# Altium schematic sheet [06] - POWER.SchDoc(POWER)
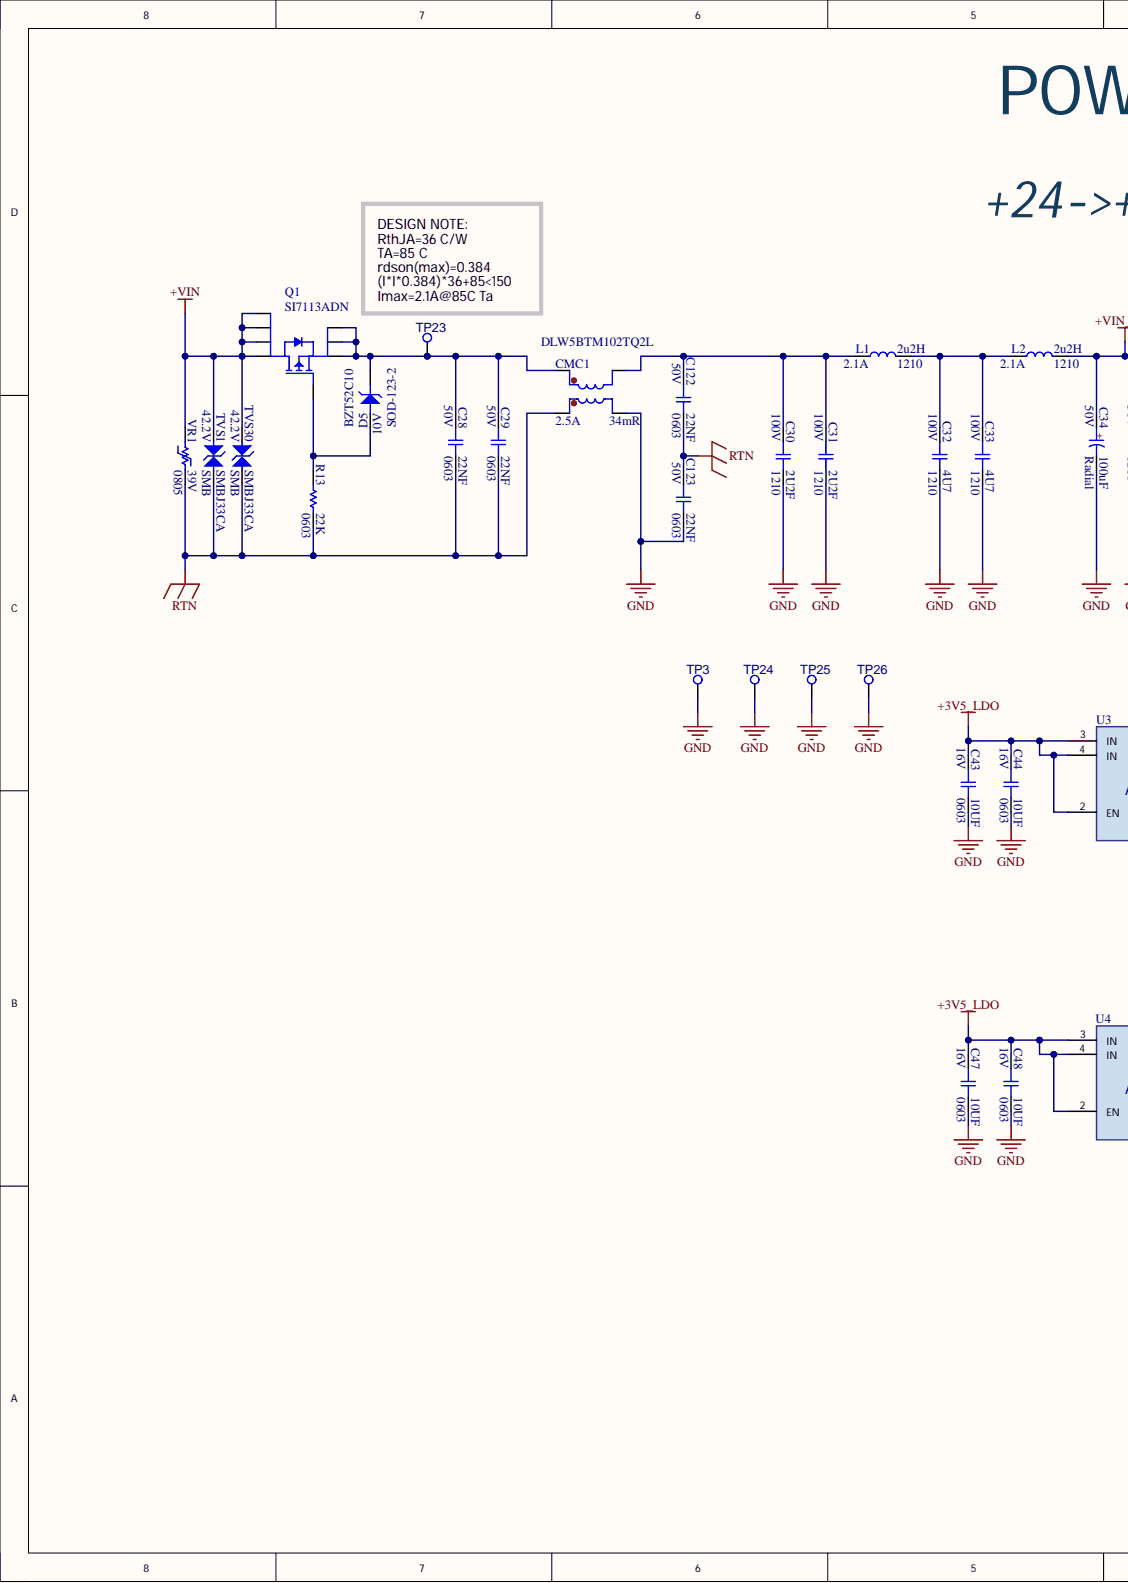
[diagram p0: [06] - POWER.SchDoc, left]
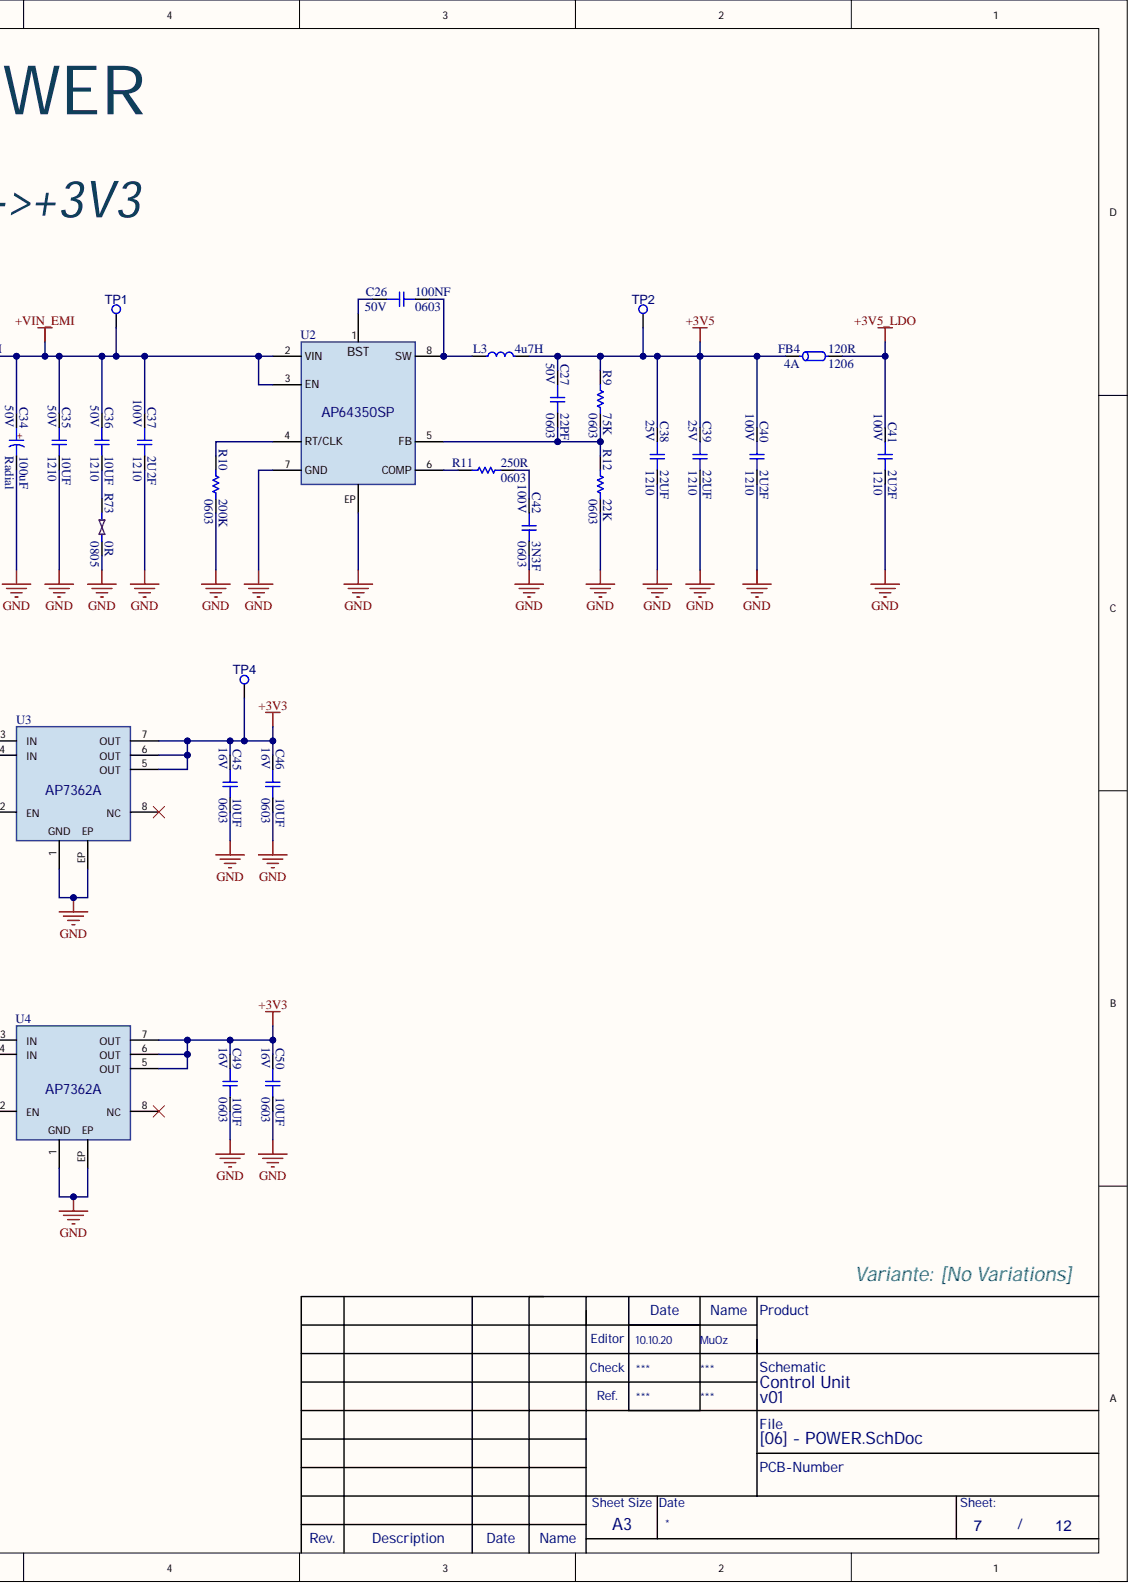
[diagram p1: [06] - POWER.SchDoc, right]

## Parts
C26  100NF  Case Code (Imperial): 0603  Voltage: 50V  [C-0603]
C27  22PF  Case Code (Imperial): 0603  Voltage: 50V  [C-0603]
C28  22NF  Case Code (Imperial): 0603  Voltage: 50V  [C-0603]
C29  22NF  Case Code (Imperial): 0603  Voltage: 50V  [C-0603]
C30  2U2F  Case Code (Imperial): 1210  Voltage: 100V  [C-1210]
C31  2U2F  Case Code (Imperial): 1210  Voltage: 100V  [C-1210]
C32  4U7  Case/Package: 1210  Voltage Rating: 100V  [C-1210]
C33  4U7  Case/Package: 1210  Voltage Rating: 100V  [C-1210]
C34  Case/Package: Radial  Voltage: 50V  [C-E-8.3x8.3x10.2]  (hidden comment: 100UF)
C35  10UF  Case Code (Imperial): 1210  Voltage: 50V  [C-1210]
C36  10UF  Case Code (Imperial): 1210  Voltage: 50V  [C-1210]
C37  2U2F  Case Code (Imperial): 1210  Voltage: 100V  [C-1210]
C38  22UF  Case Code (Imperial): 1210  Voltage: 25V  [C-1210]
C39  22UF  Case Code (Imperial): 1210  Voltage: 25V  [C-1210]
C40  2U2F  Case Code (Imperial): 1210  Voltage: 100V  [C-1210]
C41  2U2F  Case Code (Imperial): 1210  Voltage: 100V  [C-1210]
C42  3N3F  Case Code (Imperial): 0603  Voltage Rating: 100V  [C-0603]
C43  10UF  Case Code (Imperial): 0603  Voltage: 16V  [C-0603]
C44  10UF  Case Code (Imperial): 0603  Voltage: 16V  [C-0603]
C45  10UF  Case Code (Imperial): 0603  Voltage: 16V  [C-0603]
C46  10UF  Case Code (Imperial): 0603  Voltage: 16V  [C-0603]
C47  10UF  Case Code (Imperial): 0603  Voltage: 16V  [C-0603]
C48  10UF  Case Code (Imperial): 0603  Voltage: 16V  [C-0603]
C49  10UF  Case Code (Imperial): 0603  Voltage: 16V  [C-0603]
C50  10UF  Case Code (Imperial): 0603  Voltage: 16V  [C-0603]
C122  22NF  Case Code (Imperial): 0603  Voltage: 50V  [C-0603]
C123  22NF  Case Code (Imperial): 0603  Voltage: 50V  [C-0603]
CMC1  DLW5BTM102TQ2L  DC Resistance (DCR): 34mR  Max Current Rating: 2.5A  [CMC-DLW5BTM]
D5  BZT52C10  Case/Package: SOD-123-2  Voltage Rating (DC): 10V  [D-SOD123]
FB4  120R  Case Code (Imperial): 1206  Current Rating: 4A  [FB-1206]
L1  2u2H  Case Code (Imperial): 1210  Current Rating: 2.1A  [WE-PMCI_1210_H1]
L2  2u2H  Case Code (Imperial): 1210  Current Rating: 2.1A  [WE-PMCI_1210_H1]
L3  4u7H  [WE-MAPI_5030]
Q1  SI7113ADN  [PowerPAK 1212-8]
R9  75K  Case Code (Imperial): 0603  [R-0603]
R10  200K  Case Code (Imperial): 0603  [R-0603]
R11  250R  Case Code (Imperial): 0603  [R-0603]
R12  22K  Case Code (Imperial): 0603  [R-0603]
R13  22K  Case Code (Imperial): 0603  [R-0603]
R73  0R  Case Code (Imperial): 0805  [JMP-0805]
TP1  MECH-TESTPOINT  [PAD TH]  (value not drawn)  (no BOM)
TP2  MECH-TESTPOINT  [PAD TH]  (value not drawn)  (no BOM)
TP3  MECH-TESTPOINT  [PAD TH]  (value not drawn)  (no BOM)
TP4  MECH-TESTPOINT  [PAD TH]  (value not drawn)  (no BOM)
TP23  MECH-TESTPOINT  [MECH-TESTPOINT]  (value not drawn)  (no BOM)
TP24  MECH-TESTPOINT  [PAD TH]  (value not drawn)  (no BOM)
TP25  MECH-TESTPOINT  [PAD TH]  (value not drawn)  (no BOM)
TP26  MECH-TESTPOINT  [PAD TH]  (value not drawn)  (no BOM)
TVS1  SMBJ33CA  Breakdown Voltage: 42.2V  Case/Package: SMB  [D-SMB-DO214AA-CA]
TVS30  SMBJ33CA  Breakdown Voltage: 42.2V  Case/Package: SMB  [D-SMB-DO214AA-CA]
U2  AP64350SP  [SOIC8-EP]
U3  AP7362A  [SOIC8-EP]
U4  AP7362A  [SOIC8-EP]
VR1  Case Code (Imperial): 0805  Varistor Voltage: 39V  [R-0805]  (hidden comment: B72510E1250K62)

## Nets
+3V3: C45.1 C46.1 C49.1 C50.1 TP4.1 U3.5(OUT) U3.6(OUT) U3.7(OUT) U4.5(OUT) U4.6(OUT) U4.7(OUT)
+3V5: C27.1 C38.1 C39.1 C40.1 FB4.1 L3.2 R9.1 TP2.1
+3V5_LDO: C41.1 C43.1 C44.1 C47.1 C48.1 FB4.2 U3.2(EN) U3.3(IN) U3.4(IN) U4.2(EN) U4.3(IN) U4.4(IN)
+VIN: Q1.5 Q1.6 Q1.7 Q1.8 TVS1.A TVS30.A VR1.1
+VIN_EMI: C34.1 C35.1 C36.1 C37.1 L2.2 TP1.1 U2.2(VIN) U2.3(EN)
GND: C30.2 C31.2 C32.2 C33.2 C34.2 C35.2 C37.2 C38.2 C39.2 C40.2 C41.2 C42.2 C43.2 C44.2 C45.2 C46.2 C47.2 C48.2 C49.2 C50.2 C123.2 CMC1.2 R10.2 R12.2 R73.2 TP3.1 TP24.1 TP25.1 TP26.1 U2.7(GND) U2.EP U3.1 U3.EP U4.1 U4.EP
RTN: C28.2 C29.2 C122.2 C123.1 CMC1.1 R13.2 TVS1.C TVS30.C VR1.2
NetC26_1: C26.1 U2.1
NetC26_2: C26.2 L3.1 U2.8(SW)
NetC27_2: C27.2 R9.2 R12.1 U2.5(FB)
NetC28_1: C28.1 C29.1 CMC1.4 D5.C Q1.1 Q1.2 Q1.3 TP23.1
NetC30_1: C30.1 C31.1 C122.1 CMC1.3 L1.1
NetC32_1: C32.1 C33.1 L1.2 L2.1
NetC36_2: C36.2 R73.1
NetC42_1: C42.1 R11.2
NetD5_A: D5.A Q1.4 R13.1
NetR10_1: R10.1 U2.4(RT/CLK)
NetR11_1: R11.1 U2.6(COMP)

Not connected: U3 8(NC); U4 8(NC)

## Library data of the parts
C26 = C-100NF-0603: CAP CER 0.1UF 50V X7R 0603; Manufacturer: TDK; Manufacturer Part Number: C1608X7R1H104K080AA; .Creator: F:TALI; .PCBCHK: CHECKED; Dielectric Material: Ceramic; Temperature Coefficient: 30ppm/°C; Tolerance: 10%; Washability: OK; .SCHCHK: CHECKED; Capacitance: 100nF; Case Code (Metric): 1608; Case/Package: 0603; Component Kind: Standard; ComponentLink1Description: http://www.mouser.com/ds/2/400/lcc_commercial_general_en-837201.pdf; ComponentLink1URL: http://www.mouser.com/ds/2/400/lcc_commercial_general_en-837201.pdf; ComponentLink2Description: https://product.tdk.com/info/en/catalog/spec/mlccspec_commercial_general_midvoltage_en.pdf; ComponentLink2URL: https://product.tdk.com/info/en/catalog/spec/mlccspec_commercial_general_midvoltage_en.pdf; ComponentLink3Description: http://uk.rs-online.com/webdocs/13d1/0900766b813d1103.pdf; ComponentLink3URL: http://uk.rs-online.com/webdocs/13d1/0900766b813d1103.pdf; ComponentLink4Description: http://www.tdk.co.jp/tefe02/e412_c.pdf; ComponentLink4URL: http://www.tdk.co.jp/tefe02/e412_c.pdf; ComponentLink5Description: http://www.farnell.com/datasheets/2291921.pdf; ComponentLink5URL: http://www.farnell.com/datasheets/2291921.pdf; ComponentLink6Description: http://www.farnell.com/datasheets/1744655.pdf; ComponentLink6URL: http://www.farnell.com/datasheets/1744655.pdf; ComponentLink7Description: http://catalogs.avx.com/SurfaceMount.pdf; ComponentLink7URL: http://catalogs.avx.com/SurfaceMount.pdf; ComponentLink8Description: http://www.farnell.com/datasheets/630895.pdf; ComponentLink8URL: http://www.farnell.com/datasheets/630895.pdf; ComponentLink9Description: http://www.avx.com/docs/Catalogs/cx7r.pdf; ComponentLink9URL: http://www.avx.com/docs/Catalogs/cx7r.pdf; ComponentLink10Description: http://www.avx.com/docs/catalogs/cauto.pdf; ComponentLink10URL: http://www.avx.com/docs/catalogs/cauto.pdf; ComponentLink11Description: https://www.verical.com/server-webapp/html/getDatasheet?partID=98691; ComponentLink11URL: https://www.verical.com/server-webapp/html/getDatasheet?partID=98691; ComponentLink12Description: https://www.avx.com/docs/Catalogs/flexiterm.pdf; ComponentLink12URL: https://www.avx.com/docs/Catalogs/flexiterm.pdf; Composition: Ceramic; Contact Plating: Matte,Tin; Depth: 0.8mm; Dielectric: X7R; Features: Soft Termination; Height: 0.8mm; Lead Free: Lead Free; Length: 1.6mm; Max Operating Temperature: 125°C; Min Operating Temperature: -55°C; Mount: Surface Mount; Number of Pins: 2; Package Quantity: 1; Packaging: Tape and Reel; Pricing 1: 1=0.1, 10=0.057, 100=0.0254, 500=0.01812, 1000=0.01423 (USD); Pricing 2: 1=0.23, 10=0.161, 100=0.0756, 500=0.05304, 1000=0.0439 (USD); Radiation Hardening: No; REACH SVHC: No SVHC; Stock 1: 0; Stock 2: 40000; Supplier 1: Digi-Key; Supplier 2: Digi-Key; Supplier Part Number 1: 445-1314-1-ND; Supplier Part Number 2: 478-3540-6-ND; Termination: SMD/SMT; Thickness: 0.037inch; Voltage Rating: 50V; Voltage Rating (DC): 50V; Weight: 7.1E-05oz; Width: 0.8mm; Library Name: BARKO_SCHLIB.SchLib
C27 = C-22PF-0603: CAP CER 22PF 50V C0G/NP0 0603; Manufacturer: Samsung; Manufacturer Part Number: CL10C220JB8NNNC; .Creator: F:TALI; .PCBCHK: USED; Dielectric: C0G; Dielectric Material: Ceramic; Insulation Resistance: 100GR; Temperature Coefficient: 300ppm/°C; Tolerance: 5%; Washability: OK; .SCHCHK: USED; Capacitance: 22pF; Case Code (Metric): 1608; Case/Package: 0603; Component Kind: Standard; ComponentLink1Description: http://www1.futureelectronics.com/doc/SAMSUNG%20PASSIVE/CL05B104KQ5NNNC.pdf; ComponentLink1URL: http://www1.futureelectronics.com/doc/SAMSUNG%20PASSIVE/CL05B104KQ5NNNC.pdf; ComponentLink2Description: https://media.digikey.com/pdf/Data%20Sheets/Samsung%20PDFs/CL_Series_MLCC_ds.pdf; ComponentLink2URL: https://media.digikey.com/pdf/Data%20Sheets/Samsung%20PDFs/CL_Series_MLCC_ds.pdf; ComponentLink3Description: https://www.distrelec.biz/Web/Downloads/_e/ng/MLCC_CatalogDownload2013_ENG.pdf?mime=application%2Fpdf; ComponentLink3URL: https://www.distrelec.biz/Web/Downloads/_e/ng/MLCC_CatalogDownload2013_ENG.pdf?mime=application%2Fpdf; ComponentLink4Description: https://produktinfo.conrad.com/datenblaetter/450000-474999/457135-da-01-en-VS_KONDENSATOR_0603.pdf; ComponentLink4URL: https://produktinfo.conrad.com/datenblaetter/450000-474999/457135-da-01-en-VS_KONDENSATOR_0603.pdf; ComponentLink5Description: http://www.avx.com/docs/Catalogs/ccog.pdf; ComponentLink5URL: http://www.avx.com/docs/Catalogs/ccog.pdf; ComponentLink6Description: https://www.verical.com/server-webapp/html/getDatasheet?partID=60906; ComponentLink6URL: https://www.verical.com/server-webapp/html/getDatasheet?partID=60906; ComponentLink7Description: http://www.farnell.com/datasheets/759117.pdf; ComponentLink7URL: http://www.farnell.com/datasheets/759117.pdf; ComponentLink8Description: http://catalogs.avx.com/SurfaceMount.pdf; ComponentLink8URL: http://catalogs.avx.com/SurfaceMount.pdf; ComponentLink9Description: http://uk.rs-online.com/webdocs/140c/0900766b8140c8fe.pdf; ComponentLink9URL: http://uk.rs-online.com/webdocs/140c/0900766b8140c8fe.pdf; ComponentLink10Description: http://www1.futureelectronics.com/doc/SAMSUNG/CL10C220JB8NFNC.pdf; ComponentLink10URL: http://www1.futureelectronics.com/doc/SAMSUNG/CL10C220JB8NFNC.pdf; ComponentLink11Description: http://www.samsungsem.com/kr/support/product-search/mlcc/CL10C220JB8NFNC.jsp; ComponentLink11URL: http://www.samsungsem.com/kr/support/product-search/mlcc/CL10C220JB8NFNC.jsp; Contact Plating: Tin; Depth: 0.8mm; Height: 0.8mm; Lead Free: Lead Free; Length: 1.6mm; Material: Ceramic; Max Operating Temperature: 125°C; Min Operating Temperature: -55°C; Mount: Surface Mount; Number of Pins: 2; Package Quantity: 1; Packaging: Tape and Reel; Pricing 1: 1=0.1, 10=0.056, 100=0.0251, 500=0.0179, 1000=0.01406 (USD); Pricing 2: 1=0.1, 10=0.073, 100=0.0324, 500=0.02314, 1000=0.01818 (USD); Pricing 3: 1=0.1, 10=0.048, 100=0.0214, 500=0.01532, 1000=0.01203 (USD); Radiation Hardening: No; REACH SVHC: No SVHC; Stock 1: 0; Stock 2: 40000; Stock 3: 3383; Supplier 1: Digi-Key; Supplier 2: Digi-Key; Supplier 3: Digi-Key; Supplier Part Number 1: 1276-1023-6-ND; Supplier Part Number 2: 478-1167-6-ND; Supplier Part Number 3: 1276-2228-6-ND; Termination: SMD/SMT; Thickness: 0.035inch; Voltage Rating: 50V; Voltage Rating (DC): 50V; Width: 0.031inch; Library Name: BARKO_SCHLIB.SchLib
C28 C29 C122 C123 = C-22NF-0603: CAP CER 0.022UF 50V X7R 0603L; Manufacturer: Yageo; Manufacturer Part Number: CC0603KRX7R9BB223; .Creator: M.OZKAN; .PCBCHK: USED; Dielectric Material: Ceramic; Insulation Resistance: 100GR; Tolerance: 10%; Washability: OK; .SCHCHK: USED; Capacitance: 22nF; Case Code (Metric): 1608; Case/Package: 0603; Component Kind: Standard; ComponentLink1Description: https://datasheet.ciiva.com/156/mlccorderingcode-156177.pdf?src-supplier=Digi-Key; ComponentLink1URL: https://datasheet.ciiva.com/156/mlccorderingcode-156177.pdf?src-supplier=Digi-Key; ComponentLink2Description: https://datasheet.ciiva.com/156/mlccorderingcode-156178.pdf?src-supplier=Digi-Key; ComponentLink2URL: https://datasheet.ciiva.com/156/mlccorderingcode-156178.pdf?src-supplier=Digi-Key; ComponentLink3Description: https://datasheet.ciiva.com/156/mlccorderingcode-156179.pdf?src-supplier=Digi-Key; ComponentLink3URL: https://datasheet.ciiva.com/156/mlccorderingcode-156179.pdf?src-supplier=Digi-Key; ComponentLink4Description: https://datasheet.ciiva.com/11464/upy-gphc-x7r-6-3v-to-50v-8-11464741.pdf?src-supplier=Avnet; ComponentLink4URL: https://datasheet.ciiva.com/11464/upy-gphc-x7r-6-3v-to-50v-8-11464741.pdf?src-supplier=Avnet; ComponentLink5Description: https://datasheet.ciiva.com/8033/1489800-8033865.pdf?src-supplier=Element14; ComponentLink5URL: https://datasheet.ciiva.com/8033/1489800-8033865.pdf?src-supplier=Element14; ComponentLink6Description: https://datasheet.ciiva.com/8033/1489800-8033867.pdf?src-supplier=Element14; ComponentLink6URL: https://datasheet.ciiva.com/8033/1489800-8033867.pdf?src-supplier=Element14; ComponentLink7Description: https://datasheet.ciiva.com/16625/upy-gphc-x7r-6-3v-to-50v-8-16625532.pdf?src-supplier=Avnet+Europe; ComponentLink7URL: https://datasheet.ciiva.com/16625/upy-gphc-x7r-6-3v-to-50v-8-16625532.pdf?src-supplier=Avnet+Europe; ComponentLink8Description: https://datasheet.ciiva.com/8033/70765-8033869.pdf?src-supplier=Element14; ComponentLink8URL: https://datasheet.ciiva.com/8033/70765-8033869.pdf?src-supplier=Element14; ComponentLink9Description: https://datasheet.ciiva.com/28450/1489800-28450639.pdf?src-supplier=Element14; ComponentLink9URL: https://datasheet.ciiva.com/28450/1489800-28450639.pdf?src-supplier=Element14; Composition: Ceramic; Depth: 0.8mm; Dielectric: X7R; Halogen Free: Halogen Free; Height: 0.8mm; Lead Free: Lead Free; Length: 1.6mm; Max Operating Temperature: 125°C; Min Operating Temperature: -55°C; Mount: Surface Mount; Number of Pins: 2; Package Quantity: 1; Packaging: Tape and Reel; Pricing 1: 1=0.10000, 10=0.06700, 100=0.03000, 500=0.02140, 1000=0.01682 (USD); Radiation Hardening: No; REACH SVHC: No SVHC; RoHS: Yes; Stock 1: 144094; Supplier 1: Digi-Key; Supplier Part Number 1: 311-1370-1-ND; Termination: SMD/SMT; Thickness: 0.035inch; Voltage Rating: 50V; Voltage Rating (DC): 50V; Width: 0.031inch; Library Name: BARKO_SCHLIB.SchLib
C30 C31 C37 C40 C41 = C-2U2F-1210: CAP CER 2.2UF 100V X7R 1210; Manufacturer: Samsung; Manufacturer Part Number: CL32B225KCJSNNE; .Creator: F:TALI; .PCBCHK: CHECKED; Tolerance: 10%; Washability: OK; .SCHCHK: CHECKED; Capacitance: 2.2uF; Case Code (Metric): 3225; Case/Package: 1210; Component Kind: Standard; ComponentLink1Description: http://www1.futureelectronics.com/doc/SAMSUNG/CL32B225KCJSNNE.pdf; ComponentLink1URL: http://www1.futureelectronics.com/doc/SAMSUNG/CL32B225KCJSNNE.pdf; ComponentLink2Description: http://www.samsungsem.com/kr/support/product-search/mlcc/CL32B225KCJSNNE .jsp; ComponentLink2URL: http://www.samsungsem.com/kr/support/product-search/mlcc/CL32B225KCJSNNE .jsp; ComponentLink3Description: https://www.verical.com/server-webapp/html/getDatasheet?partID=357497; ComponentLink3URL: https://www.verical.com/server-webapp/html/getDatasheet?partID=357497; ComponentLink4Description: http://www.mouser.com/ds/2/400/lcc_commercial_general_en-837201.pdf; ComponentLink4URL: http://www.mouser.com/ds/2/400/lcc_commercial_general_en-837201.pdf; ComponentLink5Description: http://www.farnell.com/datasheets/1698875.pdf; ComponentLink5URL: http://www.farnell.com/datasheets/1698875.pdf; ComponentLink6Description: http://www.farnell.com/datasheets/1484596.pdf; ComponentLink6URL: http://www.farnell.com/datasheets/1484596.pdf; ComponentLink7Description: https://www.verical.com/server-webapp/html/getDatasheet?partID=725361; ComponentLink7URL: https://www.verical.com/server-webapp/html/getDatasheet?partID=725361; ComponentLink8Description: http://product.tdk.com/en/catalog/datasheets/mlcc_commercial_midvoltage_en.pdf; ComponentLink8URL: http://product.tdk.com/en/catalog/datasheets/mlcc_commercial_midvoltage_en.pdf; Composition: Ceramic; Contact Plating: Tin; Depth: 2.5mm; Dielectric: X7R; Features: Soft Termination; Height: 2.5mm; Lead Free: Lead Free; Length: 3.2mm; Max Operating Temperature: 125°C; Min Operating Temperature: -55°C; Mount: Surface Mount; Number of Pins: 2; Package Quantity: 1; Packaging: Tape and Reel; Pricing 1: 1=0.58, 10=0.41, 100=0.27, 500=0.2 (USD); Pricing 2: 1=0.92, 10=0.648, 100=0.4269, 500=0.31626 (USD); Pricing 3: 1=1.42, 10=1.121, 100=0.8409 (USD); Radiation Hardening: No; Ratings: AEC-Q200; REACH SVHC: No SVHC; Stock 1: 0; Stock 2: 0; Stock 3: 0; Supplier 1: Digi-Key; Supplier 2: Digi-Key; Supplier 3: Digi-Key; Supplier Part Number 1: 1276-3362-6-ND; Supplier Part Number 2: 445-4497-6-ND; Supplier Part Number 3: 587-4235-6-ND; Termination: SMD/SMT; Thickness: 0.106inch; Voltage Rating: 100V; Voltage Rating (DC): 100V; Weight: 0.000564oz; Width: 0.098inch; Library Name: BARKO_SCHLIB.SchLib
C32 C33 = C-4U7-1210-100V: CAP CER 4.7UF 100V X7S 1210; Manufacturer: Taiyo Yuden; Manufacturer Part Number: HMK325C7475KM-PE; Tolerance: 10%; Washability: OK; Capacitance: 4.7uF; Component Kind: Standard; ComponentLink1Description: http://ds.yuden.co.jp/TYCOMPAS/ut/detail.do?productNo=HMK325C7475KM-PE&amp;fileName=HMK325C7475KM-PE_SS&amp;mode=specSheetDownload&src-supplier=Digi-Key; ComponentLink1URL: http://ds.yuden.co.jp/TYCOMPAS/ut/detail.do?productNo=HMK325C7475KM-PE&amp;fileName=HMK325C7475KM-PE_SS&amp;mode=specSheetDownload&src-supplier=Digi-Key; Max Operating Temperature: 125°C; Min Operating Temperature: -55°C; Mount: Surface Mount; Packaging: Tape and Reel; Pricing 1: 1=1.10000, 10=0.83900, 100=0.60030, 500=0.46474 (USD); RoHS: No; Stock 1: 0; Supplier 1: Digi-Key; Supplier Part Number 1: 587-HMK325C7475KM-PECT-ND; Library Name: BARKO_SCHLIB.SchLib
C34 = C-100UF-SMD: CAP ALUM 100UF 20% 50V SMD; Manufacturer: Nichicon; Manufacturer Part Number: UUD1H101MNL1GS; .Creator: M.OZKAN; .PCBCHK: CHECKED; Dielectric Material: Aluminium; Dissipation Factor: 0.14%; Impedance: 340mR; Lead Diameter: 1.1mm; Leakage Current: 3uA; Life (Hours): 5000Hour; Ripple Current: 300mA; Washability: OK; .SCHCHK: CHECKED; Capacitance: 100uF; Component Kind: Standard; ComponentLink1Description: http://www.farnell.com/datasheets/2100017.pdf; ComponentLink1URL: http://www.farnell.com/datasheets/2100017.pdf; ComponentLink2Description: http://nichicon-us.com/english/products/pdfs/e-uud.pdf; ComponentLink2URL: http://nichicon-us.com/english/products/pdfs/e-uud.pdf; ComponentLink3Description: http://www.nichicon.co.jp/english/products/pdfs/e-ch_ref.pdf; ComponentLink3URL: http://www.nichicon.co.jp/english/products/pdfs/e-ch_ref.pdf; ComponentLink4Description: http://nichicon-us.com/english/products/pdfs/e-ud.pdf; ComponentLink4URL: http://nichicon-us.com/english/products/pdfs/e-ud.pdf; ComponentLink5Description: http://www.farnell.com/datasheets/1910936.pdf; ComponentLink5URL: http://www.farnell.com/datasheets/1910936.pdf; ComponentLink6Description: http://www.farnell.com/datasheets/66055.pdf; ComponentLink6URL: http://www.farnell.com/datasheets/66055.pdf; ComponentLink7Description: http://uk.rs-online.com/webdocs/0fa3/0900766b80fa39e7.pdf; ComponentLink7URL: http://uk.rs-online.com/webdocs/0fa3/0900766b80fa39e7.pdf; ComponentLink8Description: http://www.nichicon.co.jp/english/products/pdfs/e-ud.pdf; ComponentLink8URL: http://www.nichicon.co.jp/english/products/pdfs/e-ud.pdf; Diameter: 8mm; Height: 10mm; Height - Seated (Max): 0.394inch; Lead Free: Lead Free; Lead Pitch: 3.1mm; Length: 10mm; Max Operating Temperature: 105°C; Min Operating Temperature: -55°C; Number of Pins: 2; Package Quantity: 500; Packaging: Tape and Reel; Pricing 1: 1=0.75, 10=0.554, 100=0.3796 (USD); Radiation Hardening: No; REACH SVHC: No SVHC; Ripple Current (AC): 300mA; Stock 1: 72155; Supplier 1: Digi-Key; Supplier 2: Digi-Key; Supplier Part Number 1: 493-2306-6-ND; Supplier Part Number 2: 565-2495-6-ND; Termination: SMD/SMT; Tolerance: 20%; Voltage Rating: 50V; Voltage Rating (DC): 50V; Library Name: BARKO_SCHLIB.SchLib
C35 C36 = C-10UF-1210: CAP CER 10UF 50V X7R 1210; Manufacturer: Samsung; Manufacturer Part Number: CL32B106KBJNNNE; .Creator: M.OZKAN; .PCBCHK: CHECKED; Material: Ceramic; Tolerance: 10%; Washability: OK; .SCHCHK: CHECKED; Capacitance: 10uF; Case Code (Metric): 3225; Case/Package: 1210; Component Kind: Standard; ComponentLink1Description: http://www.samsungsem.com/kr/support/product-search/mlcc/CL32B106KBJNNNE .jsp; ComponentLink1URL: http://www.samsungsem.com/kr/support/product-search/mlcc/CL32B106KBJNNNE .jsp; ComponentLink2Description: https://produktinfo.conrad.com/datenblaetter/450000-474999/450821-da-01-en-VS_KONDENSATOR_1210.pdf; ComponentLink2URL: https://produktinfo.conrad.com/datenblaetter/450000-474999/450821-da-01-en-VS_KONDENSATOR_1210.pdf; ComponentLink3Description: https://www.yuden.co.jp/productdata/catalog/mlcc_all_e.pdf; ComponentLink3URL: https://www.yuden.co.jp/productdata/catalog/mlcc_all_e.pdf; ComponentLink4Description: http://www.samsungsem.com/kr/support/product-search/mlcc/CL32B106KBJNNWE.jsp; ComponentLink4URL: http://www.samsungsem.com/kr/support/product-search/mlcc/CL32B106KBJNNWE.jsp; Depth: 2.5mm; Dielectric: X7R; Halogen Free: Halogen Free; Height: 2.5mm; Lead Free: Lead Free; Length: 3.2mm; Max Operating Temperature: 125°C; Min Operating Temperature: -55°C; Mount: Surface Mount; Package Quantity: 1; Packaging: Tape and Reel; Pricing 1: 1=0.96, 10=0.704, 100=0.483, 500=0.38238 (USD); Pricing 2: 1=1.02, 10=0.755, 100=0.5175 (USD); Pricing 3: 1=0.86, 10=0.61, 100=0.4017, 500=0.29756 (USD); Radiation Hardening: No; Stock 1: 0; Stock 2: 0; Stock 3: 0; Supplier 1: Digi-Key; Supplier 2: Digi-Key; Supplier 3: Digi-Key; Supplier Part Number 1: 1276-3387-6-ND; Supplier Part Number 2: 587-3167-6-ND; Supplier Part Number 3: 1276-3388-6-ND; Termination: SMD/SMT; Thickness: 0.106inch; Voltage Rating: 50V; Voltage Rating (DC): 50V; Width: 0.098inch; Library Name: BARKO_SCHLIB.SchLib
C38 C39 = C-22UF-1210: CAP CER 22UF 25V X7R 1210; Manufacturer: Samsung; Manufacturer Part Number: CL32B226KAJNNNE; .Creator: F:TALI; .PCBCHK: CHECKED; Dielectric Material: Ceramic; Dissipation Factor: 10%; Washability: OK; .SCHCHK: CHECKED; Capacitance: 22uF; Case Code (Metric): 3225; Case/Package: 1210; Component Kind: Standard; ComponentLink1Description: https://media.digikey.com/pdf/Data%20Sheets/Samsung%20PDFs/CL_Series_MLCC_ds.pdf; ComponentLink1URL: https://media.digikey.com/pdf/Data%20Sheets/Samsung%20PDFs/CL_Series_MLCC_ds.pdf; ComponentLink2Description: http://www.samsungsem.com/kr/support/product-search/mlcc/CL32B226KAJNFNE.jsp; ComponentLink2URL: http://www.samsungsem.com/kr/support/product-search/mlcc/CL32B226KAJNFNE.jsp; Depth: 2.5mm; Dielectric: X7R; Height: 2.5mm; Lead Free: Lead Free; Length: 3.2mm; Material: Ceramic; Max Operating Temperature: 125°C; Min Operating Temperature: -55°C; Mount: Surface Mount; Package Quantity: 1; Packaging: Tape and Reel; Pricing 1: 1=1.02, 10=0.777, 100=0.556, 500=0.43042 (USD); Pricing 2: 1=0.91, 10=0.671, 100=0.4598, 500=0.36402 (USD); Pricing 3: 1=1.63, 10=1.289, 100=0.9664, 500=0.7302 (USD); Radiation Hardening: No; Stock 1: 0; Stock 2: 0; Stock 3: 0; Supplier 1: Digi-Key; Supplier 2: Digi-Key; Supplier 3: Digi-Key; Supplier Part Number 1: 1276-3392-1-ND; Supplier Part Number 2: 1276-3391-6-ND; Supplier Part Number 3: 399-11958-6-ND; Termination: SMD/SMT; Thickness: 0.106inch; Tolerance: 10%; Voltage Rating: 25V; Voltage Rating (DC): 25V; Width: 0.098inch; Library Name: BARKO_SCHLIB.SchLib
C42 = C-3N3F-0603: CAP CER 3300PF 100V X7R 0603; Manufacturer: TDK; Manufacturer Part Number: CGA3E2X7R2A332K080AA; .Creator: F:TALI; .PCBCHK: USED; Capacitance: 3.3nF; Tolerance: 10%; Washability: OK; .SCHCHK: USED; Case Code (Metric): 1608; Case/Package: 0603; Component Kind: Standard; ComponentLink1Description: http://www.mouser.com/ds/2/400/lcc_automotive_midvoltage_en-355485.pdf; ComponentLink1URL: http://www.mouser.com/ds/2/400/lcc_automotive_midvoltage_en-355485.pdf; ComponentLink2Description: http://www.farnell.com/datasheets/1807242.pdf; ComponentLink2URL: http://www.farnell.com/datasheets/1807242.pdf; ComponentLink3Description: http://search.murata.co.jp/Ceramy/image/img/w_hinm/S0717E.pdf; ComponentLink3URL: http://search.murata.co.jp/Ceramy/image/img/w_hinm/S0717E.pdf; ComponentLink4Description: http://psearch.murata.com/capacitor/product/GCM188R72A332KA37%23.pdf; ComponentLink4URL: http://psearch.murata.com/capacitor/product/GCM188R72A332KA37%23.pdf; Composition: Ceramic; Depth: 0.8mm; Dielectric: X7R; Height: 0.8mm; Lead Free: Lead Free; Length: 1.6mm; Max Operating Temperature: 125°C; Min Operating Temperature: -55°C; Mount: Surface Mount; Package Quantity: 4000; Packaging: Tape and Reel; Pricing 1: 1=0.1, 10=0.076, 100=0.0338, 500=0.02416, 1000=0.01898 (USD); Pricing 2: 1=0.16, 10=0.114, 100=0.0535, 500=0.03748, 1000=0.03103 (USD); Radiation Hardening: No; Ratings: AEC-Q200; REACH SVHC: No SVHC; Stock 1: 22990; Stock 2: 40000; Supplier 1: Digi-Key; Supplier 2: Digi-Key; Supplier Part Number 1: 445-5809-6-ND; Supplier Part Number 2: 490-14767-6-ND; Termination: SMD/SMT; Thickness: 0.035inch; Voltage Rating (DC): 100V; Weight: 7.1E-05oz; Width: 0.031inch; Library Name: BARKO_SCHLIB.SchLib
C43-C50 = C-10UF-0603: CAP CER 10UF 16V X6S 0603; Manufacturer: Murata; Manufacturer Part Number: GRM188C81C106MA73D; .Creator: M.OZKAN; .PCBCHK: USED; Material: Ceramic; Tolerance: 20%; Washability: OK; .SCHCHK: USED; Capacitance: 10uF; Case Code (Metric): 1608; Case/Package: 0603; Component Kind: Standard; ComponentLink1Description: https://www.murata.com/~/media/webrenewal/support/library/catalog/products/capacitor/mlcc/c02e.ashx?la=en-us; ComponentLink1URL: https://www.murata.com/~/media/webrenewal/support/library/catalog/products/capacitor/mlcc/c02e.ashx?la=en-us; Composition: Ceramic; Depth: 0.8mm; Dielectric: X6S; Height: 0.8mm; Lead Free: Lead Free; Length: 1.6mm; Max Operating Temperature: 105°C; Min Operating Temperature: -55°C; Mount: Surface Mount; Package Quantity: 4000; Packaging: Tape and Reel; Pricing 1: 1=0.53, 10=0.366, 100=0.2195, 500=0.16464, 1000=0.13903 (USD); Pricing 2: 1=0.3, 10=0.205, 100=0.1159, 500=0.08184, 1000=0.07161 (USD); REACH SVHC: No SVHC; Stock 1: 0; Stock 2: 1927; Supplier 1: Digi-Key; Supplier 2: Digi-Key; Supplier Part Number 1: 490-7198-6-ND; Supplier Part Number 2: 1276-6769-6-ND; Termination: SMD/SMT; Thickness: 0.031inch; Voltage Rating: 16V; Voltage Rating (DC): 16V; Weight: 0.000222oz; Width: 0.8mm; Library Name: BARKO_SCHLIB.SchLib
CMC1 = CMC-DLW5BTM102TQ2L: CMC 2.5A 2LN 1 KOHM SMD; Manufacturer: Murata; Impedance: 1kR; Number of Lines: 2; Voltage Rating (DC): 50V; Component Kind: Standard; ComponentLink1Description: https://www.murata.com/en-us/products/productdata/8796761587742/EFLC0025.pdf?src-supplier=Digi-Key; ComponentLink1URL: https://www.murata.com/en-us/products/productdata/8796761587742/EFLC0025.pdf?src-supplier=Digi-Key; Lead Free: Lead Free; Length: 5mm; Manufacturer Part Number: DLW5BTM102TQ2L; Max Operating Temperature: 105°C; Min Operating Temperature: -40°C; Mount: Surface Mount; Packaging: Tape and Reel; Pricing: 1=1.19, 10=1.102, 25=1.0168, 50=0.8474, 100=0.7966, 250=0.7119 (USD); RoHS: No; Stock: 6882; Supplier 1: Digi-Key; Supplier Part Number 1: 490-14403-1-ND; Width: 5mm; Library Name: BARKO_SCHLIB.SchLib
D5 = D-BZT52C10-SOD123: DIODE ZENER 10V 500MW SOD123; Manufacturer: Diodes; Manufacturer Part Number: BZT52C10-7-F; .Creator: F:TALI; .PCBCHK: CHECKED; Average Rectified Current: 250mA; Element Configuration: Single; ESD Protection: No; Forward Voltage: 900mV; Impedance: 20R; Max Forward Surge Current (Ifsm): 4A; Max Repetitive Reverse Voltage (Vrrm): 100V; Max Reverse Leakage Current: 200nA; Output Current: 50mA; Recovery Time: 4ns; Test Current: 5mA; Tolerance: 6%; Washability: OK; .SCHCHK: CHECKED; Component Kind: Standard; ComponentLink1Description: https://www.diodes.com/assets/Datasheets/ds18004.pdf; ComponentLink1URL: https://www.diodes.com/assets/Datasheets/ds18004.pdf; ComponentLink2Description: http://www.jameco.com/Jameco/Products/ProdDS/1539497.pdf; ComponentLink2URL: http://www.jameco.com/Jameco/Products/ProdDS/1539497.pdf; ComponentLink3Description: https://www.verical.com/server-webapp/html/getDatasheet?partID=110273; ComponentLink3URL: https://www.verical.com/server-webapp/html/getDatasheet?partID=110273; ComponentLink4Description: http://www.diodes.com/_files/datasheets/ds18010.pdf; ComponentLink4URL: http://www.diodes.com/_files/datasheets/ds18010.pdf; ComponentLink5Description: http://www.jameco.com/Jameco/Products/ProdDS/1541167.pdf; ComponentLink5URL: http://www.jameco.com/Jameco/Products/ProdDS/1541167.pdf; ComponentLink6Description: http://www.mouser.com/ds/2/427/mmsz5225-102133.pdf; ComponentLink6URL: http://www.mouser.com/ds/2/427/mmsz5225-102133.pdf; ComponentLink7Description: http://www.nxp.com/documents/data_sheet/BAV70_SER.pdf; ComponentLink7URL: http://www.nxp.com/documents/data_sheet/BAV70_SER.pdf; ComponentLink8Description: http://www.farnell.com/datasheets/1914003.pdf; ComponentLink8URL: http://www.farnell.com/datasheets/1914003.pdf; Contact Plating: Matte,Tin; Forward Current: 250mA; Height: 1.35mm; Lead Free: Lead Free; Length: 2.85mm; Max Operating Temperature: 150°C; Max Power Dissipation: 500mW; Max Reverse Voltage (DC): 100V; Max Surge Current: 4A; Min Operating Temperature: -65°C; Mount: Surface Mount; Number of Pins: 2; Package Quantity: 3000; Packaging: Tape and Reel; Peak Non-Repetitive Surge Current: 4A; Peak Reverse Current: 200nA; Power Dissipation: 500mW; Power Rating: 500mW; Pricing 1: 1=0.24, 10=0.218, 100=0.1186, 500=0.07296, 1000=0.04975 (USD); Pricing 2: 1=0.25, 10=0.204, 100=0.108, 500=0.07108, 1000=0.04833 (USD); Pricing 3: 1=0.3, 10=0.244, 25=0.2044, 100=0.1295, 250=0.09992, 500=0.08516, 1000=0.05791 (USD); Radiation Hardening: No; REACH SVHC: No SVHC; Reverse Recovery Time: 4ns; Stock 1: 339; Stock 2: 34933; Stock 3: 14290; Supplier 1: Digi-Key; Supplier 2: Digi-Key; Supplier 3: Digi-Key; Supplier Part Number 1: BZT52C10-FDIDKR-ND; Supplier Part Number 2: MMSZ5240B-FDIDKR-ND; Supplier Part Number 3: MMSZ5240B-HE3-08GICT-ND; Termination: SMD/SMT; Voltage Tolerance: 6%; Weight: 0.000353oz; Width: 1.7mm; Working Voltage: 10V; Zener Current: 5mA; Zener Voltage: 10V; Library Name: BARKO_SCHLIB.SchLib
FB4 = FB-120R-1206: FERRITE BEAD 120 OHM 1206 1LN; Manufacturer: Laird; Manufacturer Part Number: HI1206P121R-10; .Creator: F:TALI; .PCBCHK: USED; DC Resistance (DCR): 30mR; Frequency: 100MHz; Number of Lines: 1; Washability: OK; .SCHCHK: USED; Case Code (Metric): 3216; Case/Package: 1206; Component Kind: Standard; ComponentLink1Description: http://assets.lairdtech.com/home/brandworld/files/HI1206P121R-10.pdf; ComponentLink1URL: http://assets.lairdtech.com/home/brandworld/files/HI1206P121R-10.pdf; ComponentLink2Description: http://www.farnell.com/datasheets/1685744.pdf; ComponentLink2URL: http://www.farnell.com/datasheets/1685744.pdf; ComponentLink3Description: http://lairdtech.thomasnet.com/Asset/HI1206P121R-10-A-VP.pdf; ComponentLink3URL: http://lairdtech.thomasnet.com/Asset/HI1206P121R-10-A-VP.pdf; Contact Plating: Matte,Tin; Core Material: Ferrite; Depth: 1.8mm; Height: 0.051inch; Impedance: 120R; Lead Free: Lead Free; Length: 3.2mm; Material: Ferrite; Max Current Rating: 4A; Max DC Current: 4A; Max Operating Temperature: 125°C; Min Operating Temperature: -40°C; Mount: Surface Mount; Package Quantity: 1; Packaging: Tape and Reel; Pricing 1: 1=0.18, 10=0.15, 25=0.1164, 50=0.0966, 100=0.0833, 250=0.0666, 500=0.05994, 1000=0.04995 (USD); REACH SVHC: Unknown; Stock 1: 375681; Supplier 1: Digi-Key; Supplier Part Number 1: 240-2410-6-ND; Termination: SMD/SMT; Test Frequency: 100MHz; Weight: 0.000944oz; Width: 1.6mm; Library Name: BARKO_SCHLIB.SchLib
L1 L2 = L-2u2H-74479299222: FIXED IND 2.2UH 2.1A 106 MOHM; Manufacturer: Wurth Electronics; DC Resistance (DCR): 106mR; Inductance Tolerance: 20%; Saturation Current: 3.5A; Self Resonant Frequency: 25MHz; Shielding: Shielded; Test Frequency: 1MHz; Case Code (Metric): 3225; Case/Package: 1210; Component Kind: Standard; ComponentLink1Description: http://www.farnell.com/datasheets/2040044.pdf?src-supplier=Element14; ComponentLink1URL: http://www.farnell.com/datasheets/2040044.pdf?src-supplier=Element14; ComponentLink2Description: https://katalog.we-online.de/pbs/datasheet/74479299222.pdf?src-supplier=Digi-Key; ComponentLink2URL: https://katalog.we-online.de/pbs/datasheet/74479299222.pdf?src-supplier=Digi-Key; Height - Seated (Max): 0.047inch; Inductance: 2.2uH; Length: 0.126inch; Manufacturer Part Number: 74479299222; Max Operating Temperature: 125°C; Min Operating Temperature: -40°C; Mount: Surface Mount; Packaging: Tape and Reel; Pricing: 1=1.23, 50=1.078, 100=0.935, 1000=0.836 (USD); REACH SVHC: Unknown; RoHS: Yes; Stock: 4027; Supplier 1: Digi-Key; Supplier Part Number 1: 732-9728-1-ND; Tolerance: 20%; Width: 0.098inch; Library Name: BARKO_SCHLIB.SchLib
L3 = L-4u7H-74438367047: FIXED IND 4.7UH 5.5A 34.5MOHM; Manufacturer: Wurth Electronics; Component Kind: Standard; Manufacturer Part Number: 74438367047; Pricing: 1=1.95, 10=1.77, 50=1.62, 100=1.48 (USD); RoHS: No; Stock: 930; Supplier 1: Digi-Key; Supplier Part Number 1: 732-74438367047CT-ND; Library Name: BARKO_SCHLIB.SchLib
Q1 = Q-SI7113ADN-T1-GE3: MOSFET P-CH 100V 10.8A 1212-8; Manufacturer: Vishay; .Creator: M.OZKAN; .PCBCHK: USED; Input Capacitance: 515pF; Max Power Dissipation: 27.8W; Rds On Max: 132mR; Washability: OK; .SCHCHK: USED; Component Kind: Standard; ComponentLink1Description: https://www.vishay.com/docs/77678/si7113adn.pdf?src-supplier=Digi-Key; ComponentLink1URL: https://www.vishay.com/docs/77678/si7113adn.pdf?src-supplier=Digi-Key; ComponentLink2Description: http://www.farnell.com/datasheets/2687468.pdf?src-supplier=Farnell; ComponentLink2URL: http://www.farnell.com/datasheets/2687468.pdf?src-supplier=Farnell; ComponentLink3Description: http://www.farnell.com/datasheets/2687468.pdf?src-supplier=Element14; ComponentLink3URL: http://www.farnell.com/datasheets/2687468.pdf?src-supplier=Element14; ComponentLink4Description: http://www.farnell.com/datasheets/2687468.pdf?src-supplier=Newark; ComponentLink4URL: http://www.farnell.com/datasheets/2687468.pdf?src-supplier=Newark; Continuous Drain Current (ID): 10.8A; Drain to Source Voltage (Vdss): 100V; Manufacturer Part Number: SI7113ADN-T1-GE3; Max Operating Temperature: 150°C; Min Operating Temperature: -55°C; Mount: Surface Mount; Packaging: Cut Tape; Pricing: 1=0.63, 10=0.542, 25=0.5056, 100=0.3757, 500=0.2933, 1000=0.2384 (USD); Pricing 1: 1=0.65000, 10=0.55800, 100=0.38700, 500=0.30214, 1000=0.24559 (USD); RoHS: No; Stock: 19112; Stock 1: 12735; Supplier 1: Digi-Key; Supplier Part Number 1: SI7113ADN-T1-GE3CT-ND; Library Name: BARKO_SCHLIB.SchLib
R9 = R-75K-0603: RES 100K OHM 1% 1/10W 0603; Manufacturer: Stackpole Electronics; Manufacturer Part Number: RMCF0603FT100K; .Creator: M.OZKAN; .PCBCHK: USED; Max Power Dissipation: 100mW; Resistance: 100kR; Temperature Coefficient: 100ppm/°C; Washability: OK; Working Voltage: 75V; .SCHCHK: USED; Case Code (Metric): 1608; Case/Package: 0603; Component Kind: Standard; ComponentLink1Description: https://datasheet.ciiva.com/15193/getdatasheetpartid-362597-15193437.pdf?src-supplier=Verical; ComponentLink1URL: https://datasheet.ciiva.com/15193/getdatasheetpartid-362597-15193437.pdf?src-supplier=Verical; Composition: Thick Film; Contact Plating: Tin,Matte; Depth: 0.8mm; Features: Automotive AEC-Q200; Height: 0.022inch; Lead Free: Lead Free; Length: 1.55mm; Max Operating Temperature: 155°C; Military Standard: Not; Min Operating Temperature: -55°C; Mount: Surface Mount; Number of Terminations: 2; Packaging: Tape and Reel; Power Rating: 100mW; Pricing 1: 1=0.10000, 10=0.01600, 100=0.00650, 1000=0.00292, 2500=0.00253 (USD); Radiation Hardening: No; RoHS: Yes; Stock 1: 1975649; Supplier 1: Digi-Key; Supplier Part Number 1: RMCF0603FT100KCT-ND; Tolerance: 1%; Width: 0.031inch; Library Name: BARKO_SCHLIB.SchLib
R10 = R-200K-0603: RES 200K OHM 1% 1/10W 0603; Manufacturer: Stackpole Electronics; Manufacturer Part Number: RMCF0603FT200K; Max Power Dissipation: 100mW; Resistance: 200kR; Temperature Coefficient: 100ppm/°C; Case Code (Metric): 1608; Case/Package: 0603; Component Kind: Standard; ComponentLink1Description: https://datasheet.ciiva.com/15193/getdatasheetpartid-369944-15193452.pdf?src-supplier=Verical; ComponentLink1URL: https://datasheet.ciiva.com/15193/getdatasheetpartid-369944-15193452.pdf?src-supplier=Verical; Composition: Thick Film; Contact Plating: Tin,Matte; Depth: 0.8mm; Features: Automotive AEC-Q200; Height: 0.022inch; Lead Free: Lead Free; Length: 1.55mm; Max Operating Temperature: 155°C; Military Standard: Not; Min Operating Temperature: -55°C; Mount: Surface Mount; Number of Terminations: 2; Packaging: Tape and Reel; Power Rating: 100mW; Pricing: 1=0.1, 10=0.015, 100=0.0063, 1000=0.0028, 2500=0.0024 (USD); Radiation Hardening: No; RoHS: Yes; Stock: 196828; Supplier 1: Digi-Key; Supplier Part Number 1: RMCF0603FT200KCT-ND; Tolerance: 1%; Width: 0.031inch; Library Name: BARKO_SCHLIB.SchLib
R11 = R-250R-0603: RES 250 OHM 0.01% 1/10W 0603; Manufacturer: Stackpole Electronics; Manufacturer Part Number: RNCF0603TKY250R; Max Power Dissipation: 100mW; Temperature Coefficient: 5ppm/°C; Case Code (Metric): 1608; Case/Package: 0603; Component Kind: Standard; ComponentLink1Description: https://www.seielect.com/catalog/SEI-Packaging.pdf?src-supplier=Digi-Key; ComponentLink1URL: https://www.seielect.com/catalog/SEI-Packaging.pdf?src-supplier=Digi-Key; Features: Moisture Resistant; Height: 0.022inch; Length: 0.063inch; Max Operating Temperature: 155°C; Min Operating Temperature: -55°C; Mount: Surface Mount; Number of Terminations: 2; Packaging: Tape and Reel; Pricing: 1=1.86, 10=1.65, 100=1.26 (USD); Resistance: 250R; Resistor Type: Thin Film; RoHS: Yes; Stock: 3231; Supplier 1: Digi-Key; Supplier Part Number 1: RNCF0603TKY250RCT-ND; Tolerance: 0.01%; Width: 0.031inch; Library Name: BARKO_SCHLIB.SchLib
R12 R13 = R-22K-0603: RES SMD 22K OHM 1% 1/8W 0603; Manufacturer: Vishay Beyschlag; Manufacturer Part Number: MCT06030C2202FP500; .Creator: F:TALI; .PCBCHK: USED; Max Power Dissipation: 125mW; Resistance: 22kR; Temperature Coefficient: 50ppm/°C; Voltage Rating: 75V; Washability: OK; .SCHCHK: USED; Case Code (Metric): 1608; Case/Package: 0603; Component Kind: Standard; ComponentLink1Description: http://www.vishay.com/docs/28705/mc_pro.pdf; ComponentLink1URL: http://www.vishay.com/docs/28705/mc_pro.pdf; Composition: Thin Film; Contact Plating: Tin; Depth: 0.85mm; Height: 0.022inch; Lead Free: Lead Free; Length: 0.061inch; Max Operating Temperature: 125°C; Military Standard: Not; Min Operating Temperature: -55°C; Number of Terminations: 2; Package Quantity: 5000; Packaging: Tape and Reel; Power Rating: 125mW; Pricing 1: 1=0.18, 10=0.152, 100=0.0596, 1000=0.02495, 2500=0.02287 (USD); Radiation Hardening: No; REACH SVHC: No SVHC; Stock 1: 132469; Supplier 1: Digi-Key; Supplier Part Number 1: MCT0603-22.0K-CFDKR-ND; Tolerance: 1%; Voltage Rating (DC): 75V; Width: 0.033inch; Library Name: BARKO_SCHLIB.SchLib
R73 = R-0R-0805: RES SMD 0 OHM JUMPER 1/8W 0805; Manufacturer: Yageo; Manufacturer Part Number: RC0805JR-070RL; .Creator: F:TALI; .PCBCHK: USED; Current Rating: 1A; Max Power Dissipation: 100mW; Power Rating: 125mW; Resistance: 0mR; Temperature Coefficient: 200ppm/°C; Tolerance: 5%; Voltage Rating: 150V; Washability: OK; Working Voltage: 75V; .SCHCHK: USED; Case Code (Metric): 2012; Case/Package: 0805; Component Kind: Standard; ComponentLink1Description: http://www.farnell.com/datasheets/1738694.pdf; ComponentLink1URL: http://www.farnell.com/datasheets/1738694.pdf; ComponentLink2Description: http://documents.rs-components.com/techlib://itc_doclist_GB.htm?stockno=6185129; ComponentLink2URL: http://documents.rs-components.com/techlib://itc_doclist_GB.htm?stockno=6185129; ComponentLink3Description: https://www.verical.com/server-webapp/html/getDatasheet?partID=330685; ComponentLink3URL: https://www.verical.com/server-webapp/html/getDatasheet?partID=330685; ComponentLink4Description: http://www.farnell.com/datasheets/1868787.pdf; ComponentLink4URL: http://www.farnell.com/datasheets/1868787.pdf; ComponentLink5Description: http://www.farnell.com/datasheets/443971.pdf; ComponentLink5URL: http://www.farnell.com/datasheets/443971.pdf; ComponentLink6Description: http://www.yageo.com/documents/recent/PYu-RC_Group_51_RoHS_L_9.pdf; ComponentLink6URL: http://www.yageo.com/documents/recent/PYu-RC_Group_51_RoHS_L_9.pdf; ComponentLink7Description: http://documents.rs-components.com/techlib://itc_doclist_GB.htm?stockno=5048827; ComponentLink7URL: http://documents.rs-components.com/techlib://itc_doclist_GB.htm?stockno=5048827; ComponentLink8Description: http://www.farnell.com/datasheets/1678796.pdf; ComponentLink8URL: http://www.farnell.com/datasheets/1678796.pdf; ComponentLink9Description: http://www.farnell.com/datasheets/2371628.pdf; ComponentLink9URL: http://www.farnell.com/datasheets/2371628.pdf; ComponentLink10Description: http://industrial.panasonic.com/www-data/pdf/AOA0000/AOA0000CE1.pdf; ComponentLink10URL: http://industrial.panasonic.com/www-data/pdf/AOA0000/AOA0000CE1.pdf; ComponentLink11Description: http://industrial.panasonic.com/www-cgi/jvcr13pz.cgi?E+PZ+3+AOA0001+ERJ6GEY0R00V+7+WW; ComponentLink11URL: http://industrial.panasonic.com/www-cgi/jvcr13pz.cgi?E+PZ+3+AOA0001+ERJ6GEY0R00V+7+WW; Composition: Thick Film; Contact Plating: Matte,Tin; Depth: 1.25mm; Features: Moisture Resistant; Height: 0.5mm; Lead Free: Lead Free; Length: 2mm; Max Operating Temperature: 155°C; Military Standard: Not; Min Operating Temperature: -55°C; Mount: Surface Mount; Number of Pins: 2; Number of Terminations: 2; Package Quantity: 5000; Packaging: Tape and Reel; Pricing 1: 1=0.1, 10=0.037, 25=0.0272, 50=0.0206, 100=0.0153, 250=0.01164, 500=0.0093, 1000=0.00685 (USD); Pricing 2: 100=0.0199, 1000=0.0089, 5000=0.0043, 15000=0.0036, 50000=0.0032 (USD); Pricing 3: 1=0.1, 10=0.06, 25=0.0432, 50=0.033, 100=0.0243, 250=0.01852, 500=0.01482, 1000=0.0109 (USD); Radiation Hardening: No; REACH SVHC: Unknown; Stock 1: 0; Stock 2: 10294500; Stock 3: 3725312; Supplier 1: Digi-Key; Supplier Part Number 1: 311-0.0ARDKR-ND; Termination: SMD/SMT; Voltage Rating (DC): 150V; Width: 1.25mm; Library Name: BARKO_SCHLIB.SchLib
TP1-TP4 TP23-TP26 = MECH-TESTPOINT: .Creator: F:TALI; .PCBCHK: USED; Washability: OK; .SCHCHK: USED; Library Name: BARKO_SCHLIB.SchLib
TVS1 TVS30 = TVS-SMBJ33CA-SMB: DIODE TVS 33VWM 53.3VC SMB; Manufacturer: Bourns; .Creator: M.OZKAN; .PCBCHK: USED; Clamping Voltage: 53.3V; Direction: Bidirectional; Element Configuration: Single; ESD Protection: Yes; Leakage Current: 5uA; Max Power Dissipation: 600W; Max Surge Current: 100A; Min Breakdown Voltage: 36.7V; Number of Bidirectional Channels: 1; Operating Supply Voltage: 3.5V; Peak Pulse Current: 11.3A; Power Line Protection: No; Reverse Standoff Voltage: 33V; Test Current: 1mA; Washability: OK; .SCHCHK: USED; Component Kind: Standard; ComponentLink1Description: https://datasheet.ciiva.com/25624/0900766b81122151-25624666.pdf?src-supplier=RSComponents; ComponentLink1URL: https://datasheet.ciiva.com/25624/0900766b81122151-25624666.pdf?src-supplier=RSComponents; ComponentLink2Description: https://datasheet.ciiva.com/26787/smbj33ca-26787423.pdf?src-supplier=IIIC; ComponentLink2URL: https://datasheet.ciiva.com/26787/smbj33ca-26787423.pdf?src-supplier=IIIC; ComponentLink3Description: https://datasheet.ciiva.com/3022/smbj-3022538.pdf?src-supplier=Avnet; ComponentLink3URL: https://datasheet.ciiva.com/3022/smbj-3022538.pdf?src-supplier=Avnet; ComponentLink4Description: https://datasheet.ciiva.com/8031/1677318-8031157.pdf?src-supplier=Element14; ComponentLink4URL: https://datasheet.ciiva.com/8031/1677318-8031157.pdf?src-supplier=Element14; ComponentLink5Description: https://datasheet.ciiva.com/334/smbj-334697.pdf?src-supplier=Digi-Key; ComponentLink5URL: https://datasheet.ciiva.com/334/smbj-334697.pdf?src-supplier=Digi-Key; ComponentLink6Description: https://datasheet.ciiva.com/334/smbj-334698.pdf?src-supplier=Digi-Key; ComponentLink6URL: https://datasheet.ciiva.com/334/smbj-334698.pdf?src-supplier=Digi-Key; ComponentLink7Description: https://datasheet.ciiva.com/334/smbj-334699.pdf?src-supplier=Digi-Key; ComponentLink7URL: https://datasheet.ciiva.com/334/smbj-334699.pdf?src-supplier=Digi-Key; ComponentLink8Description: https://datasheet.ciiva.com/8031/1677318-8031068.pdf?src-supplier=Element14; ComponentLink8URL: https://datasheet.ciiva.com/8031/1677318-8031068.pdf?src-supplier=Element14; ComponentLink9Description: https://datasheet.ciiva.com/16237/smbj-16237484.pdf?src-supplier=Onlinecomponents.com; ComponentLink9URL: https://datasheet.ciiva.com/16237/smbj-16237484.pdf?src-supplier=Onlinecomponents.com; ComponentLink10Description: https://datasheet.ciiva.com/28410/1677318-28410077.pdf?src-supplier=Element14; ComponentLink10URL: https://datasheet.ciiva.com/28410/1677318-28410077.pdf?src-supplier=Element14; ComponentLink11Description: https://datasheet.ciiva.com/3021/smbj-3021438.pdf?src-supplier=Avnet; ComponentLink11URL: https://datasheet.ciiva.com/3021/smbj-3021438.pdf?src-supplier=Avnet; ComponentLink12Description: https://datasheet.ciiva.com/48712/001056318-da-01-en-tvs-diode-smbj33ca-do-214aa-bou-48712570.pdf?src-supplier=Conrad; ComponentLink12URL: https://datasheet.ciiva.com/48712/001056318-da-01-en-tvs-diode-smbj33ca-do-214aa-bou-48712570.pdf?src-supplier=Conrad; Composition: Zener; Height: 2.42mm; Length: 4.57mm; Manufacturer Part Number: SMBJ33CA; Max Breakdown Voltage: 42.2V; Max Operating Temperature: 150°C; Max Reverse Leakage Current: 5uA; Min Operating Temperature: -55°C; Mount: Surface Mount; Number of Channels: 1; Number of Elements: 1; Number of Pins: 2; Package Quantity: 1; Packaging: Tape and Reel; Peak Pulse Power: 600W; Polarity: Bidirectional; Pricing 1: 1=0.35000, 10=0.28700, 25=0.26240, 100=0.16490, 250=0.16304, 500=0.15266, 1000=0.10380 (USD); Radiation Hardening: No; REACH SVHC: No SVHC; Reverse Breakdown Voltage: 36.7V; RoHS: Yes; Stock 1: 22606; Supplier 1: Digi-Key; Supplier Part Number 1: SMBJ33CABCT-ND; Termination: SMD/SMT; Width: 3.94mm; Library Name: BARKO_SCHLIB.SchLib
U2 = IC-AP64350SP-13: DCDC CONV HV BUCK SO-8EP; Manufacturer 1: Diodes; Component Kind: Standard; Library Name: SCHLIB.SchLib; Manufacturer Part Number: AP64350SP-13; Pricing: 1=1,73000, 10=1,55200, 25=1,46440, 100=1,17160, 250=1,02516, 500=0,99586, 1000=0,79083 (USD); RoHS: No; Stock: 3790; Supplier 1: Digi-Key; Supplier Part Number 1: 31-AP64350SP-13CT-ND
U3 U4 = IC-AP7362A-33SP-13: IC REG LINEAR 3.3V 1.5A 8SO; Manufacturer: Diodes; Accuracy: 1.5%; Max Input Voltage: 5.5V; Min Input Voltage: 2.2V; Number of Outputs: 1; Output Type: Fixed; Polarity: Positive; Quiescent Current: 25nA; Case/Package: SOP; Component Kind: Standard; ComponentLink1Description: http://www.mouser.com/ds/2/115/AP7362-476331.pdf?src-supplier=Mouser; ComponentLink1URL: http://www.mouser.com/ds/2/115/AP7362-476331.pdf?src-supplier=Mouser; ComponentLink2Description: http://diodes.com/datasheets/AP7362.pdf?src-supplier=Avnet; ComponentLink2URL: http://diodes.com/datasheets/AP7362.pdf?src-supplier=Avnet; ComponentLink3Description: https://www.diodes.com/assets/Datasheets/AP7362.pdf?src-supplier=Digi-Key; ComponentLink3URL: https://www.diodes.com/assets/Datasheets/AP7362.pdf?src-supplier=Digi-Key; Contact Plating: Tin; Library Name: SCHLIB.SchLib; Manufacturer Part Number: AP7362A-33SP-13; Max Operating Temperature: 85°C; Max Output Current: 1.5A; Min Operating Temperature: -40°C; Mount: Surface Mount; Number of Pins: 8; Number of Regulators: 1; Output Current: 1.5A; Output Voltage: 3.3V; Packaging: Tape and Reel; Pricing: 1=0.6, 10=0.511, 25=0.4772, 100=0.3545, 250=0.3, 500=0.2863, 1000=0.2113 (USD); RoHS: Yes; Stock: 3699; Supplier 1: Digi-Key; Supplier Part Number 1: AP7362A-33SP-13DICT-ND
VR1 = VR-B72510E1250K62: VARISTOR 39V 80A 0805; Manufacturer: TDK EPCOS; .Creator: F:TALI; .PCBCHK: USED; Capacitance: 250pF; Clamping Current: 1A; Clamping Voltage: 67V; Energy: 0.3J; Max Varistor Voltage: 42.9V; Max Voltage Rating (AC): 25V; Max Voltage Rating (DC): 31V; Min Varistor Voltage: 35.1V; Number of Circuits: 1; Tolerance: 10%; Washability: OK; .SCHCHK: USED; Case Code (Metric): 2012; Case/Package: 0805; Component Kind: Standard; ComponentLink1Description: http://www.mouser.com/ds/2/400/Automotive_series-525688.pdf; ComponentLink1URL: http://www.mouser.com/ds/2/400/Automotive_series-525688.pdf; Depth: 1.25mm; Length: 2mm; Manufacturer Part Number: B72510E1250K62; Max Operating Temperature: 125°C; Max Surge Current: 80A; Min Operating Temperature: -55°C; Mount: Surface Mount; Package Quantity: 1; Packaging: Tape and Reel; Stock 1: 0; Supplier 1: Digi-Key; Supplier Part Number 1: 495-4614-1-ND; Termination: SMD/SMT; Voltage Rating (AC): 25V; Voltage Rating (DC): 31V; Voltage Tolerance: 10%; Library Name: BARKO_SCHLIB.SchLib
